# Altium schematic sheet E53_IA1.SchDoc
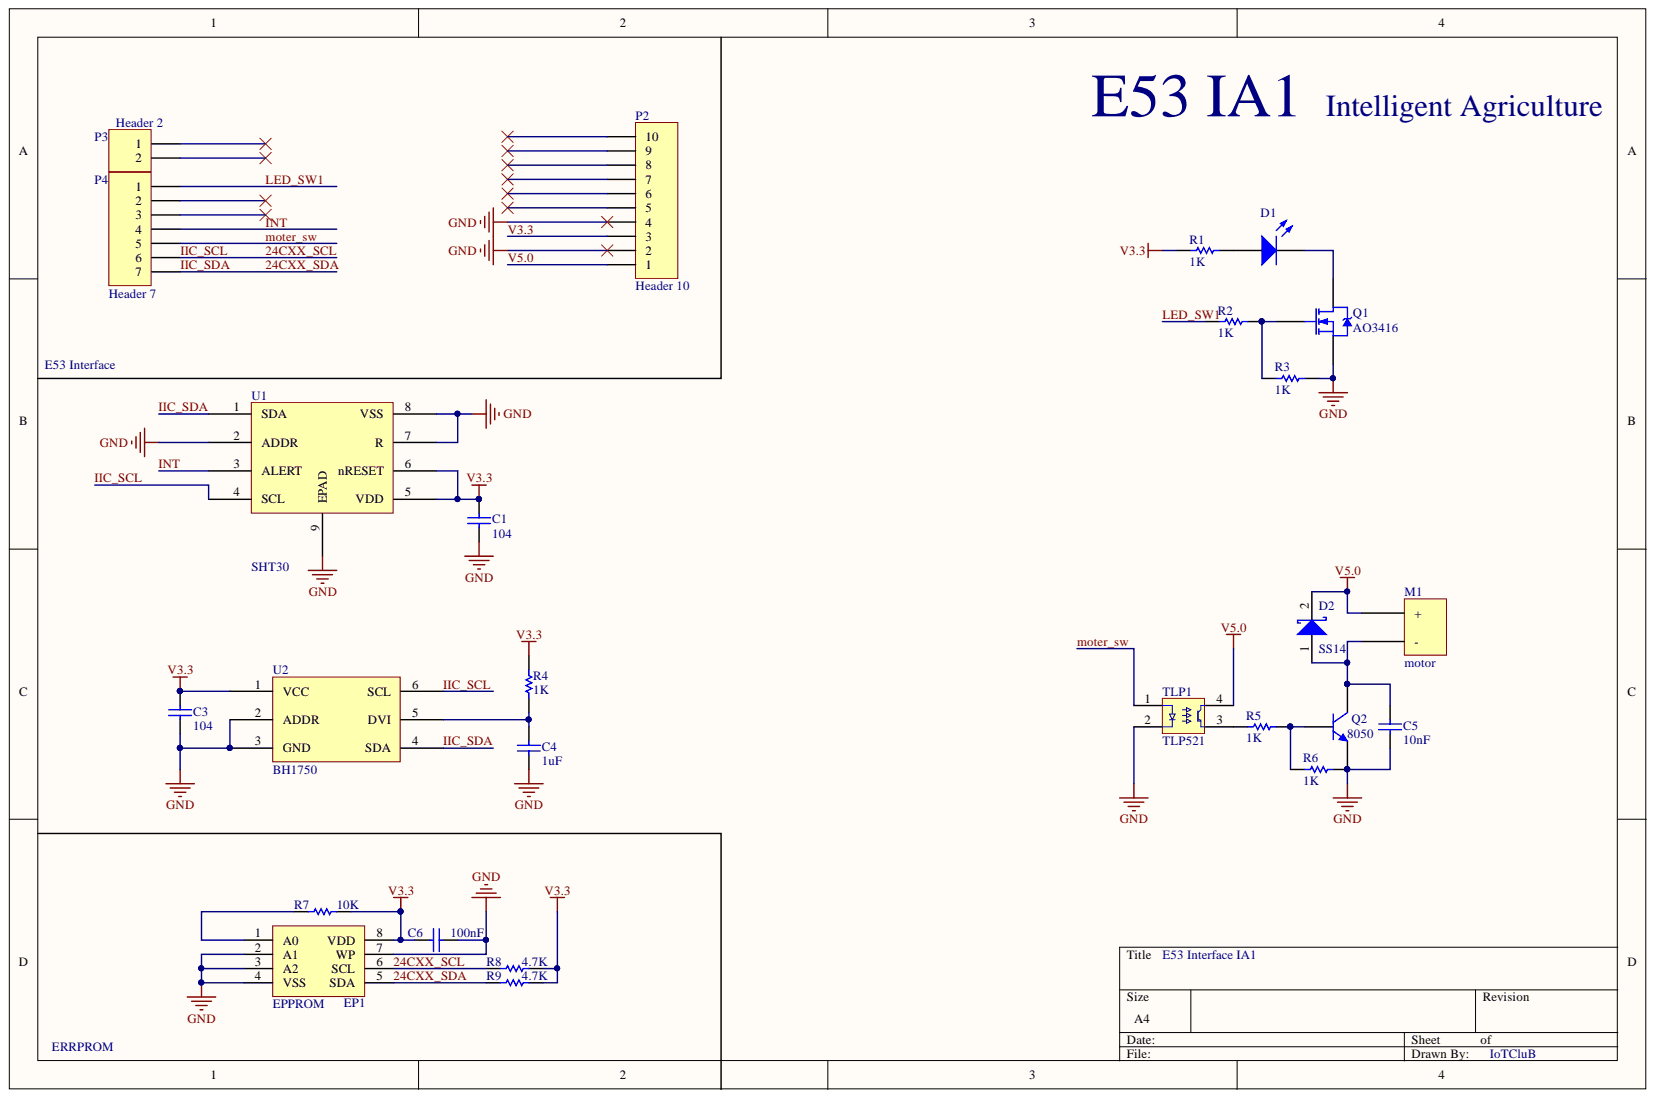
[diagram p0: E53_IA1.SchDoc]

## Parts
C1  104  [C0603]
C3  104  [C0603]
C4  1uF  [C0603]
C5  10nF  [C0603]
C6  100nF  [C0603]
D1  LED  [LED_5730]  (value not drawn)
D2  SS14  [SMA]
EP1  EPPROM  [SO-8]
M1  motor  [motor]
P2  Header 10  [HDR1X10]
P3  Header 2  [HDR1X2]
P4  Header 7  [HDR1X7]
Q1  AO3416  [SOT23_N]
Q2  8050  [SOT23_N - 123]
R1  1K  [R1206]
R2  1K  [R0603]
R3  1K  [R0603]
R4  1K  [R0603]
R5  1K  [R0603]
R6  1K  [R0603]
R7  10K  [R0603]
R8  4.7K  [R0603]
R9  4.7K  [R0603]
TLP1  TLP521  [TOP-4]
U1  SHT30  [SHT30]
U2  BH1750  [BH1750]

## Nets
GND: C1.1 C3.1 C4.1 C5.1 C6.1 EP1.2 EP1.3 EP1.4 EP1.7 P2.2 P2.4 Q1.3 Q2.2 R3.2 R6.2 TLP1.2 U1.2 U1.7 U1.8 U1.9 U2.2 U2.3
24CXX_SCL: EP1.6 P4.6 R8.2 U1.4 U2.6
24CXX_SDA: EP1.5 P4.7 R9.2 U1.1 U2.4
INT: P4.4 U1.3
LED_SW1: P4.1 R2.1
moter_sw: P4.5 TLP1.1
V3.3: C1.2 C3.2 C6.2 EP1.8 P2.3 R1.1 R4.2 R7.2 R8.1 R9.1 U1.5 U1.6 U2.1
V5.0: D2.2 M1.1 P2.1 TLP1.4
NetC4_2: C4.2 R4.1 U2.5
NetC5_2: C5.2 D2.1 M1.2 Q2.3
NetD1_1: D1.1 R1.2
NetD1_2: D1.2 Q1.2
NetEP1_1: EP1.1 R7.1
NetQ1_1: Q1.1 R2.2 R3.1
NetQ2_1: Q2.1 R5.1 R6.1
NetR5_2: R5.2 TLP1.3

Not connected: P2 5 6 7 8 9 10; P3 1 2; P4 2 3

## Library data of the parts
C1 C3-C6 = CAP: Capacitor; Component Kind: Standard; Library Name: Devices.IntLib
D1 = LED1: Typical RED GaAs LED; Component Kind: Standard; LatestRevisionDate: 08-Jul-2005; LatestRevisionNote: Mech Layer 1 removed.; Library Name: Devices.IntLib; PackageDescription: LED; 2 Leads; PackageReference: LED-1; Published: 8-Jun-2000; Simulation: LED1; Publisher: Altium Limited
D2 = D Schottky: Schottky Diode; Code_JEDEC: DO-214-AA; Component Kind: Standard; LatestRevisionDate: 17-Jul-2002; LatestRevisionNote: Re-released for DXP Platform.; Library Name: Devices.IntLib; PackageDescription: DO-214-AA/SMB; 2 C-Bend Leads; Body 5.3 x 3.6 mm, inc. leads (LxW); PackageReference: SMB; Published: 8-Jun-2000; Signal Integrity: D Schottky; Simulation: SKYDIODE; Publisher: Altium Limited
EP1 = EPPROM: ROM: 24C02; Component Kind: Standard; Library Name: IC.IntLib
P2 = Header 10: Header, 10-Pin; Component Kind: Standard; LatestRevisionDate: 17-Jul-2002; LatestRevisionNote: Re-released for DXP Platform.; Library Name: Connectors.IntLib; Signal Integrity: Connector; Publisher: Altium Limited
P3 = Header 2: Header, 2-Pin; Component Kind: Standard; LatestRevisionDate: 17-Jul-2002; LatestRevisionNote: Re-released for DXP Platform.; Library Name: Connectors.IntLib; Signal Integrity: Connector; Publisher: Altium Limited
P4 = Header 7: Header, 7-Pin; Component Kind: Standard; LatestRevisionDate: 17-Jul-2002; LatestRevisionNote: Re-released for DXP Platform.; Library Name: Connectors.IntLib; Signal Integrity: Connector; Publisher: Altium Limited
Q1 = NMOS-2: N-Channel Power MOSFET; Component Kind: Standard; Library Name: Devices.IntLib; Simulation: IRF1010
Q2 = NPN: NPN Bipolar Transistor; Code_JEDEC: TO-226-AA; Component Kind: Standard; LatestRevisionDate: 17-Jul-2002; LatestRevisionNote: Re-released for DXP Platform.; Library Name: Devices.IntLib; PackageDescription: TO, Flat Index; 3 In-Line, Axial Leads; Body Dia. 4.8mm; Leads 0.55 x 0.51 mm (max); PackageReference: TO-226-AA; Published: 8-Jun-2000; Signal Integrity: NPN; Simulation: NPN; Publisher: Altium Limited
R1-R6 = Res1: Resistor; Component Kind: Standard; LatestRevisionDate: 17-Jul-2002; LatestRevisionNote: Re-released for DXP Platform.; Library Name: Devices.IntLib; PackageDescription: Axial Device, Thru-Hole; 2 Leads; 0.3 in Pin Spacing; PackageReference: AXIAL-0.3; Published: 8-Jun-2000; Signal Integrity: Res; Simulation: RESISTOR; Value: 1K; Publisher: Altium Limited
R7-R9 = Res1: Resistor; Value: 1K; Component Kind: Standard; LatestRevisionDate: 17-Jul-2002; LatestRevisionNote: Re-released for DXP Platform.; Library Name: Devices.IntLib; PackageDescription: Axial Device, Thru-Hole; 2 Leads; 0.3 in Pin Spacing; PackageReference: AXIAL-0.3; Published: 8-Jun-2000; Signal Integrity: Res; Simulation: RESISTOR; Publisher: Altium Limited
M1 = motor: Component Kind: Standard; Library Name: Schlib1.SchLib
TLP1 = TLP521: Component Kind: Standard; Library Name: IC.IntLib
U1 = SHT30: Component Kind: Standard; Library Name: Devices.IntLib
U2 = BH1750: Component Kind: Standard; Library Name: Devices.IntLib
